# Altium schematic sheet main.SchDoc
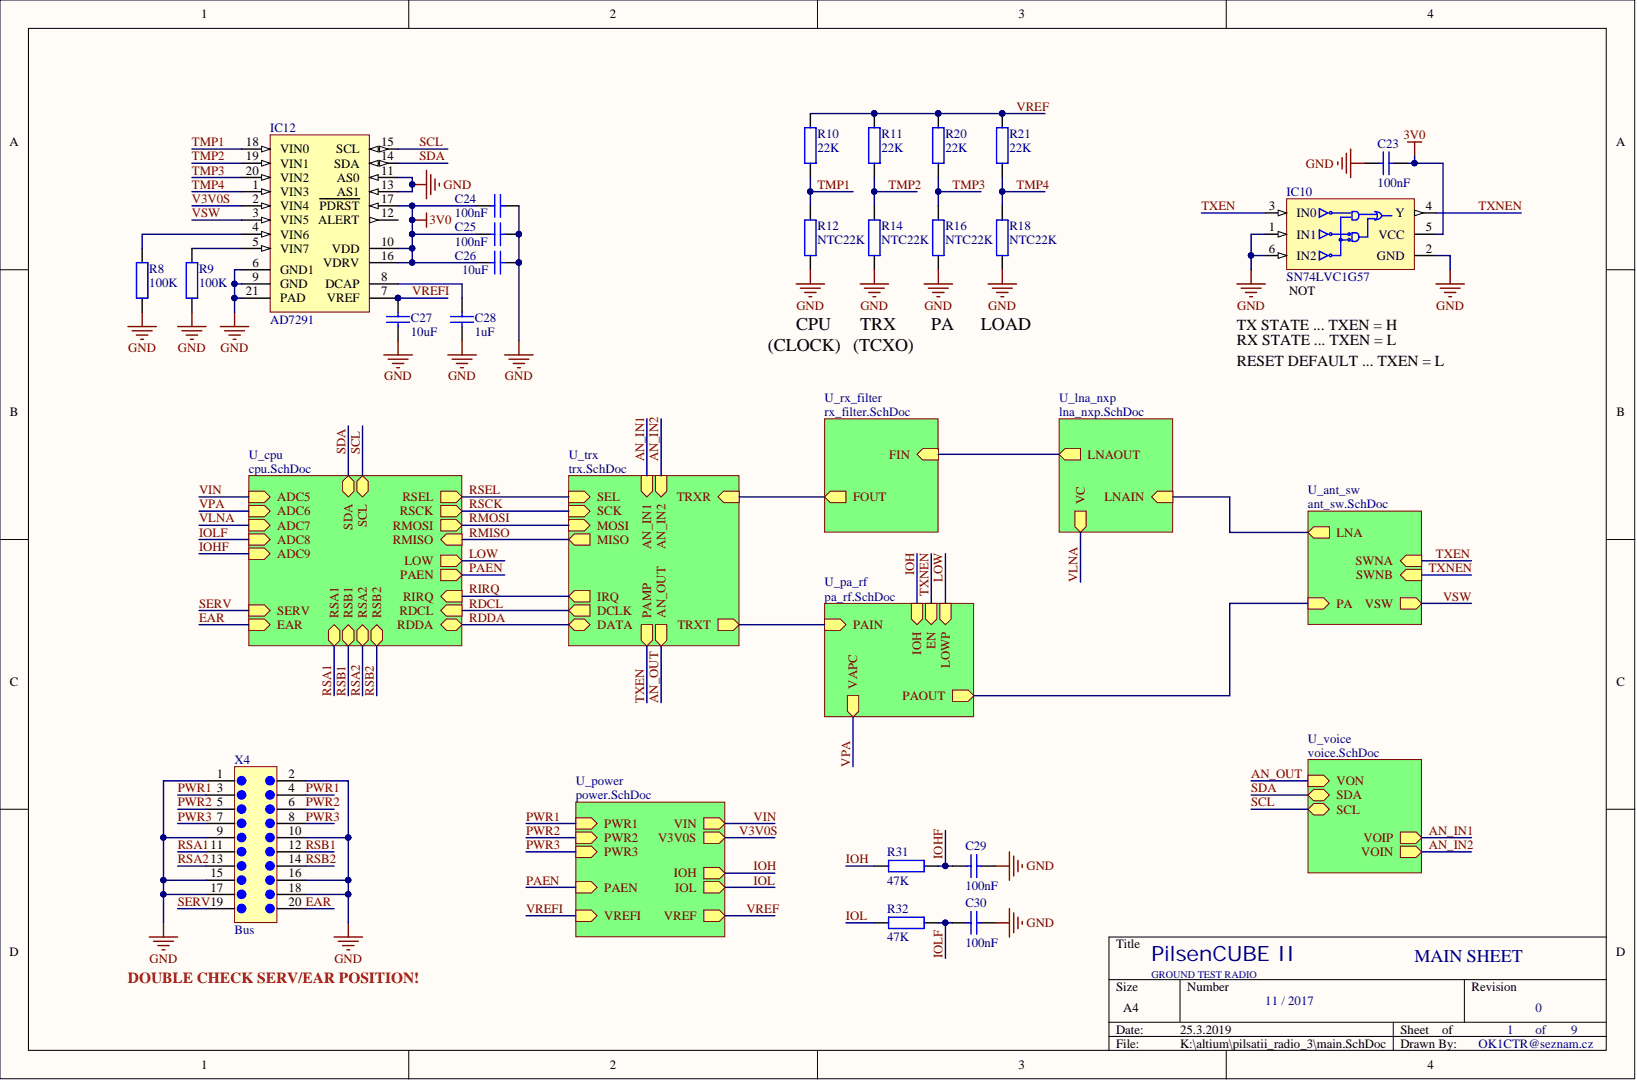
[diagram p0: main.SchDoc]

## Parts
C23  100nF  [C_0603]
C24  100nF  [C_0603]
C25  100nF  [C_0603]
C26  10uF  [C_0805]
C27  10uF  [C_0805]
C28  1uF  [C_0805]
C29  100nF  [C_0603]
C30  100nF  [C_0603]
IC10  SN74LVC1G57  [TSOP65P210X110-6N]
IC12  AD7291  [QFN50P400X400X80_HS-21N]
R8  100K  [R_0603]
R9  100K  [R_0603]
R10  22K  [R_0603]
R11  22K  [R_0603]
R12  NTC22K  [RESC1608X09N]
R14  NTC22K  [RESC1608X09N]
R16  NTC22K  [RESC1608X09N]
R18  NTC22K  [RESC1608X09N]
R20  22K  [R_0603]
R21  22K  [R_0603]
R31  47K  [R_0603]
R32  47K  [R_0603]
X4  Bus  [TH_CON_HDR2x10_PIT79]

## Nets
3V0: C23.2 C24.1 C25.1 C26.2 IC10.5(VCC) IC12.10(VDD) IC12.16(VDRV) IC12.17(PDRST)
GND: C23.1 C24.2 C25.2 C26.1 C27.1 C28.1 C29.2 C30.2 IC10.1(IN1) IC10.2(GND) IC10.6(IN2) IC12.6(GND1) IC12.9(GND) IC12.11(AS0) IC12.13(AS1) IC12.21(PAD) R8.1 R9.1 R12.1 R14.1 R16.1 R18.1 X4.1 X4.2 X4.9 X4.10 X4.15 X4.16 X4.17 X4.18
EAR: X4.20
IOH: R31.2
IOHF: C29.1 R31.1
IOL: R32.2
IOLF: C30.1 R32.1
PWR1: X4.3 X4.4
PWR2: X4.5 X4.6
PWR3: X4.7 X4.8
RSA1: X4.11
RSA2: X4.13
RSB1: X4.12
RSB2: X4.14
SCL: IC12.15(SCL)
SDA: IC12.14(SDA)
SERV: X4.19
TMP1: IC12.18(VIN0) R10.1 R12.2
TMP2: IC12.19(VIN1) R11.1 R14.2
TMP3: IC12.20(VIN2) R16.2 R20.2
TMP4: IC12.1(VIN3) R18.2 R21.2
TXEN: IC10.3(IN0)
TXNEN: IC10.4(Y)
V3V0S: IC12.2(VIN4)
VREF: R10.2 R11.2 R20.1 R21.1
VREFI: C27.2 IC12.7(VREF)
VSW: IC12.3(VIN5)
NetC28_2: C28.2 IC12.8(DCAP)
NetIC12_4: IC12.4(VIN6) R8.2
NetIC12_5: IC12.5(VIN7) R9.2
NetIC12_12: IC12.12(ALERT)
AN_IN1:
AN_IN2:
AN_OUT:
FOUT:
LNA:
LNAOUT:
LOW:
PA:
PAEN:
PAIN:
RDCL:
RDDA:
RIRQ:
RMISO:
RMOSI:
RSCK:
RSEL:
VIN:
VLNA:
VPA:

## Library data of the parts
C23-C25 C29 C30 = C: Generic Chip Capacitor; Type: SMT; Component Kind: Standard; PackageReference: C_0603; Published: 16.1.2018; Value: 100nF; Library Name: libctr2_capacitors.IntLib; Publisher: OK1CTR
C26 C27 = C: Generic Chip Capacitor; Manufacturer: Samsung; Manuf Part Number: CL21A106KOQNNNE; Type: SMT; Component Kind: Standard; PackageReference: C_0805; Published: 4.1.2018; Value: 10uF; Library Name: libctr2_capacitors.IntLib; Publisher: OK1CTR
C28 = C: Generic Chip Capacitor; Manufacturer: AVX; Manuf Part Number: 08053C105KAZ2A; Type: SMT; Component Kind: Standard; PackageReference: C_0805; Published: 4.1.2018; Value: 1uF; Library Name: libctr2_capacitors.IntLib; Publisher: OK1CTR
IC10 = (no symbol name): Configurable Multiple-Function Gate; Manufacturer: Texas Instruments; Manuf Part Number: SN74LVC1G57DCKR; Supp Part number: 1470833; Component Kind: Standard; ComponentLink1Description: Datasheet; Library Name: radio_power.SchLib; ManufacturerURL: http://www.ti.com/; PackageReference: SC-70; Published: 16.1.2018; Supplier: Farnell; Supplier2: N/A; Supplier3: N/A; SupplierURL: http://cz.farnell.com/; SupplierURL2: N/A; SupplierURL3: N/A; Supp Part number 2: N/A; Supp Part number 3: N/A; Type: SMT; Value: SN74LVC1G57; Publisher: OK1CTR
IC12 = (no symbol name): I2Cbus Digital Temperature Sensor and Thermal Watchdog; Manufacturer: Analog Devices; Manuf Part Number: AD7291BCPZ; Supp Part number: 1897104; Component Kind: Standard; ComponentLink1Description: Datasheet; Library Name: radio_power.SchLib; ManufacturerURL: https://www.analog.com; PackageReference: LFCSP-20; Published: 21.12.2018; Supplier: Farnell; Supplier2: N/A; Supplier3: N/A; SupplierURL: https://cz.farnell.com; SupplierURL2: N/A; SupplierURL3: N/A; Supp Part number 2: N/A; Supp Part number 3: N/A; Type: SMT; Value: AD7291; Publisher: OK1CTR
R8-R11 R20 R21 R31 R32 = R: Generic Chip Resistor; Component Kind: Standard; PackageReference: R_0603; Published: 16.1.2018; Type: SMT; Library Name: libctr2_resistors.IntLib; Publisher: OK1CTR
  R8 R9: Value: 100K
  R10 R11 R20 R21: Value: 22K
  R31 R32: Value: 47K
R12 R14 R16 R18 = (no symbol name): SMD 0603, Glass Protected NTC Thermistors; 22kOhm; 5%; Manufacturer: Vishay; Manuf Part Number: NTCS0603E3223JMT; Supp Part number: 2103169; Component Kind: Standard; ComponentLink1Description: Datasheet; Library Name: radio_power.SchLib; ManufacturerURL: http://www.vishay.com/; PackageReference: R_0603; Published: 16.1.2018; Supplier: Farnell; Supplier2: N/A; Supplier3: N/A; SupplierURL: http://cz.farnell.com/; SupplierURL2: N/A; SupplierURL3: N/A; Supp Part number 2: N/A; Supp Part number 3: N/A; Type: SMT; Value: NTC22K; Publisher: OK1CTR
X4 = Bus: MiliGrid 20pin; Manufacturer: Molex; Manuf Part Number: 87833-2020; Supp Part number: 7472234; Component Kind: Standard; ManufacturerURL: https://www.molex.com; PackageReference: Milli-Grid; Published: 7.1.2019; Supplier: Farnell; Supplier2: N/A; Supplier3: N/A; SupplierURL: http://cz.farnell.com; SupplierURL2: N/A; SupplierURL3: N/A; Supp Part number 2: N/A; Supp Part number 3: N/A; Type: THT; Value: Bus; Library Name: Zdroj.SchLib; Publisher: OK1CTR
